# Altium schematic sheet SCIENCE_LED_BOARD.SchDoc
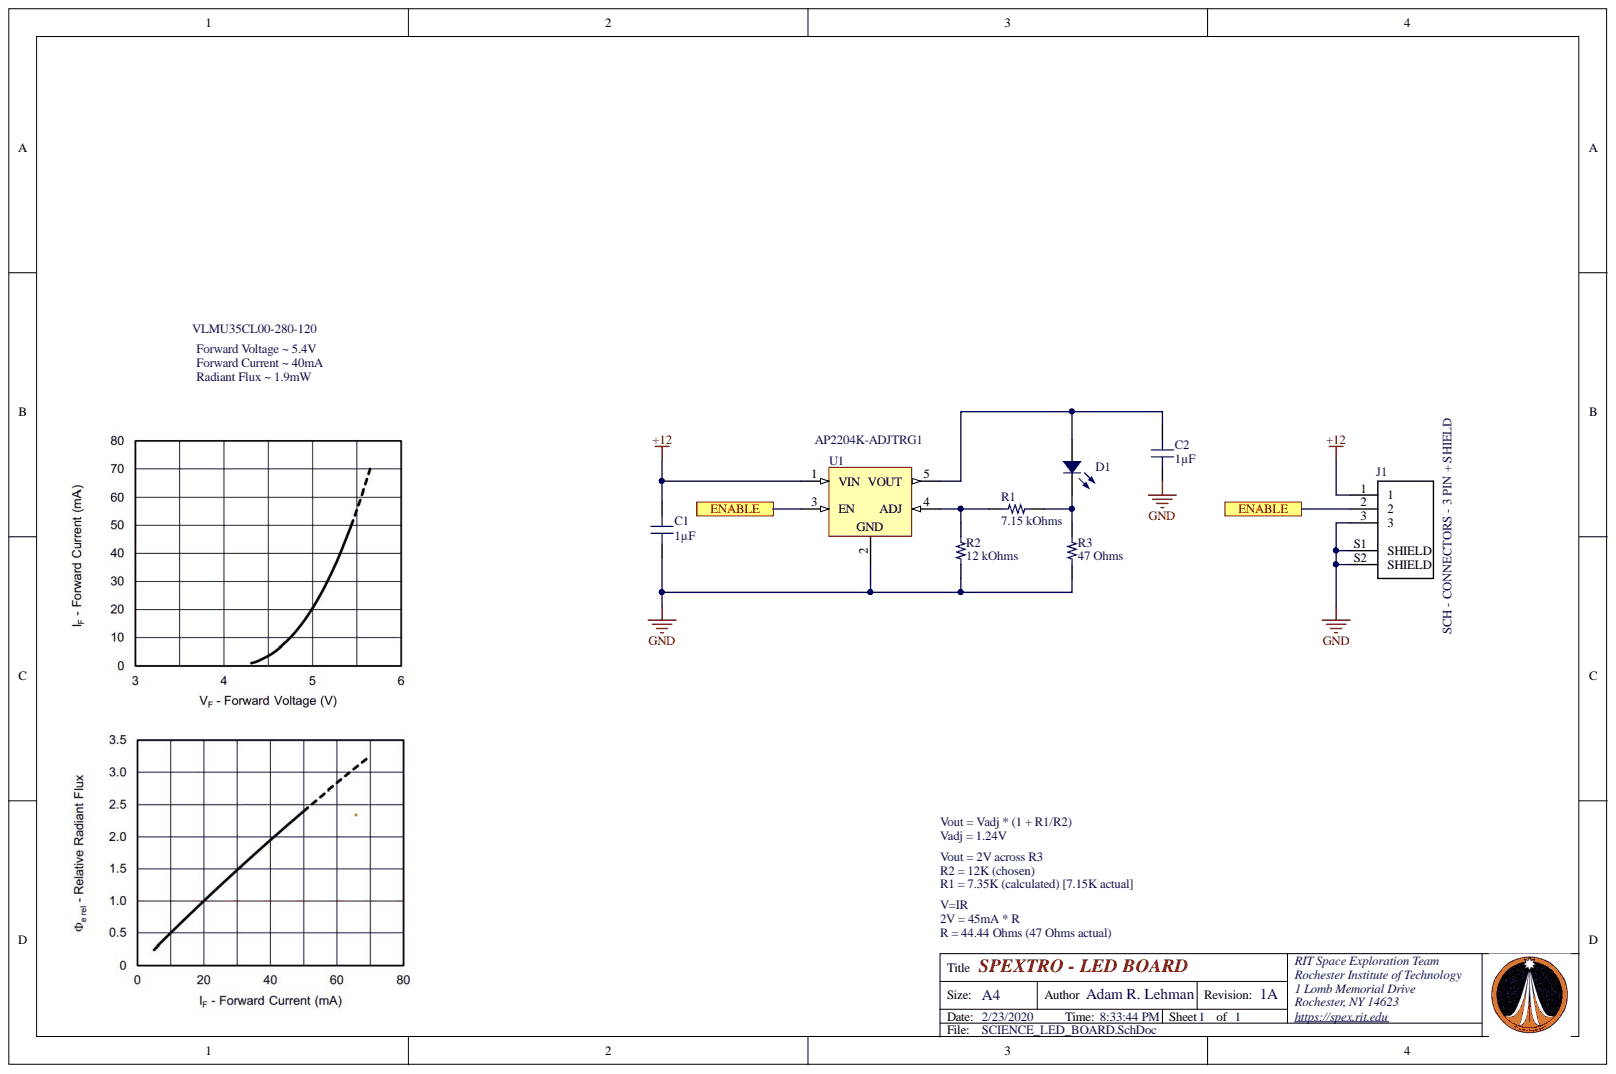
[diagram p0: SCIENCE_LED_BOARD.SchDoc]

## Parts
C1  1µF  [CAP 0603_1608]
C2  1µF  [CAP 0603_1608]
D1  =VALUE  [PCB - LED - VISHAY 1414_3535 UV]
J1  SCH - CONNECTORS - 3 PIN + SHIELD  [PCB - CONNECTOR - MOLEX - PICO-LOCK 5037630391]
R1  7.15 kOhms  [RES 0603_1608]
R2  12 kOhms  [RES 0603_1608]
R3  47 Ohms  [RES 0603_1608]
U1  AP2204K-ADJTRG1  [DIOD-SOT23-5_V]

## Ports
ENABLE

## Nets
+12: C1.2 J1.1 U1.1(VIN)
GND: C1.1 C2.1 J1.3 J1.S1 J1.S2 R2.1 R3.1 U1.2
ENABLE: J1.2 U1.3(EN) ; port ENABLE
NetC2_2: C2.2 D1.1 U1.5(VOUT)
NetD1_2: D1.2 R1.2 R3.2
NetR1_1: R1.1 R2.2 U1.4(ADJ)

## Library data of the parts
C1 C2 = CC0603KRX5R8BB105: CAP CER 1UF 25V X5R 0603; Manufacturer: Yageo; Database Table Name: Capacitors - Ceramic - 0603; Tolerance: ±10%; Applications: General Purpose; Capacitance: 1µF; Component Kind: Standard; ComponentLink1Description: Datasheet; ComponentLink1URL: https://www.yageo.com/upload/media/product/productsearch/datasheet/mlcc/UPY-GPHC_X5R_4V-to-50V_25.pdf; ComponentLink2Description: DigiKey Link; ComponentLink2URL: http://digikey.com/product-detail/en/yageo/CC0603KRX5R8BB105/311-1445-1-ND/2833751; Device Package: 0603 (1608 Metric); LastUpdated: 1/21/2020 12:02:05 AM; Library Name: Celestial Altium Library - altium_library.DbLib; Lifecycle Status: Active; Minimum Order: 1; Mounting Type: Surface Mount, MLCC; Operating Temperature: -55°C ~ 85°C; Package / Case: 0603 (1608 Metric); Packaging: TapeAndReel; PartId: 5146; Part Number: CC0603KRX5R8BB105; Part Status: Active; Price: 0.01; Series: CC; Size / Dimension: 0.063" L x 0.031" W (1.60mm x 0.80mm); Supplier 1: DigiKey; Supplier Part Number 1: 311-1445-1-ND; Temperature Coefficient: X5R; Thickness (Max): 0.035" (0.90mm); Value: 1µF; Voltage - Rated: 25V
D1 = LED: Chip LED; Component Kind: Standard; Library Name: ScienceLEDLibrary.IntLib
J1 = SCH - CONNECTORS - 3 PIN + SHIELD: Connector Header Surface Mount, Right Angle 4 position 0.039 _1.00mm_; MF: Molex; MP: 5037630491; Availability: Unavailable; Component Kind: Standard; Package: None; Price: None; SnapEDA_Link: https://www.snapeda.com/parts/5037630491/Molex/view-part/3215405/?ref=snap; Library Name: ScienceLEDLibrary.IntLib
R1 = RMCF0603FT7K15: RES 7.15K OHM 1% 1/10W 0603; Manufacturer: Stackpole Electronics Inc; Database Table Name: Resistors - Surface Mount - 0603; Power (Watts): 0.1W, 1/10W; Temperature Coefficient: ±100ppm/°C; Component Kind: Standard; ComponentLink1Description: Datasheet; ComponentLink1URL: https://www.seielect.com/catalog/sei-packaging.pdf; ComponentLink2Description: DigiKey Link; ComponentLink2URL: http://digikey.com/product-detail/en/stackpole-electronics-inc/RMCF0603FT7K15/RMCF0603FT7K15CT-ND/1943048; Composition: Thick Film; Device Package: 0603 (1608 Metric); Features: Automotive AEC-Q200; Height - Seated (Max): 0.022" (0.55mm); LastUpdated: 1/21/2020 12:17:41 AM; Library Name: Celestial Altium Library - altium_library.DbLib; Lifecycle Status: Active; Minimum Order: 1; Number of Terminations: 2; Operating Temperature: -55°C ~ 155°C; Package / Case: 0603 (1608 Metric); Packaging: CutTape; PartId: 22551; Part Number: RMCF0603FT7K15; Part Status: Active; Price: 0.10; Ratings: AEC-Q200; Resistance: 7.15 kOhms; Series: RMCF; Size / Dimension: 0.061" L x 0.031" W (1.55mm x 0.80mm); Supplier 1: DigiKey; Supplier Device Package: 0603; Supplier Part Number 1: RMCF0603FT7K15CT-ND; Tolerance: ±1%; Value: 7.15 kOhms
R2 = RMCF0603FT12K0: RES 12K OHM 1% 1/10W 0603; Manufacturer: Stackpole Electronics Inc; Database Table Name: Resistors - Surface Mount - 0603; Power (Watts): 0.1W, 1/10W; Temperature Coefficient: ±100ppm/°C; Component Kind: Standard; ComponentLink1Description: Datasheet; ComponentLink1URL: https://www.seielect.com/catalog/sei-rmcf_rmcp.pdf; ComponentLink2Description: DigiKey Link; ComponentLink2URL: http://digikey.com/product-detail/en/stackpole-electronics-inc/RMCF0603FT12K0/RMCF0603FT12K0CT-ND/1943062; Composition: Thick Film; Device Package: 0603 (1608 Metric); Features: Automotive AEC-Q200; Height - Seated (Max): 0.022" (0.55mm); LastUpdated: 1/21/2020 12:22:42 AM; Library Name: Celestial Altium Library - altium_library.DbLib; Lifecycle Status: Active; Minimum Order: 1; Number of Terminations: 2; Operating Temperature: -55°C ~ 155°C; Package / Case: 0603 (1608 Metric); Packaging: CutTape; PartId: 10636; Part Number: RMCF0603FT12K0; Part Status: Active; Price: 0.10; Ratings: AEC-Q200; Resistance: 12 kOhms; Series: RMCF; Size / Dimension: 0.061" L x 0.031" W (1.55mm x 0.80mm); Supplier 1: DigiKey; Supplier Device Package: 0603; Supplier Part Number 1: RMCF0603FT12K0CT-ND; Tolerance: ±1%; Value: 12 kOhms
R3 = RMCF0603FT47R0: RES 47 OHM 1% 1/10W 0603; Manufacturer: Stackpole Electronics Inc; Database Table Name: Resistors - Surface Mount - 0603; Power (Watts): 0.1W, 1/10W; Temperature Coefficient: ±100ppm/°C; Component Kind: Standard; ComponentLink1Description: Datasheet; ComponentLink1URL: https://www.seielect.com/catalog/sei-rmcf_rmcp.pdf; ComponentLink2Description: DigiKey Link; ComponentLink2URL: http://digikey.com/product-detail/en/stackpole-electronics-inc/RMCF0603FT47R0/RMCF0603FT47R0CT-ND/1942954; Composition: Thick Film; Device Package: 0603 (1608 Metric); Features: Automotive AEC-Q200; Height - Seated (Max): 0.022" (0.55mm); LastUpdated: 1/21/2020 12:22:37 AM; Library Name: Celestial Altium Library - altium_library.DbLib; Lifecycle Status: Active; Minimum Order: 1; Number of Terminations: 2; Operating Temperature: -55°C ~ 155°C; Package / Case: 0603 (1608 Metric); Packaging: CutTape; PartId: 10672; Part Number: RMCF0603FT47R0; Part Status: Active; Price: 0.10; Ratings: AEC-Q200; Resistance: 47 Ohms; Series: RMCF; Size / Dimension: 0.061" L x 0.031" W (1.55mm x 0.80mm); Supplier 1: DigiKey; Supplier Device Package: 0603; Supplier Part Number 1: RMCF0603FT47R0CT-ND; Tolerance: ±1%; Value: 47 Ohms
U1 = CMP-2000-05784-1: Wide Input Voltage Range, Adjustable Output, 150 mA, -40 to 125 degC, 5-Pin SOT23, RoHS, Tape and Reel; Brand: DiodesIncorporated; Dropout Voltage - Max: 500mV; Input Voltage MAX: 24V; Input Voltage MIN: 2.3V; Line Regulation: 0.05%; Load Regulation: 0.5%; Number of Outputs: 1Output; Output Current: 200mA; Output Voltage: Adj; PSRR / Ripple Rejection - Typ: 60dB; Reference Voltage: 1.24V; Unit Weight: 0.001058oz; Component Kind: Standard; Datasheet URL: https://www.diodes.com/_files/datasheets/AP2204.pdf; Datasheet Version: Rev. 3-2, 06/2016; Factory Pack Quantity: 3000; Library Name: Altium Content Vault; Manufacturer: DiodesIncorporated; Manufacturer URL: http://www.diodes.com/; Maximum Operating Temperature: +85C; Minimum Operating Temperature: -40C; Mounting Style: SMD/SMT; Mounting Technology: SurfaceMount; Output Type: Adjustable; Package / Case: SOT-23-5; Package Description: 5-Pin Small Outline Transistor, Body 2.96 x 1.6 mm, Pitch 0.95 mm; Package Reference: SOT23-5; Packaging: Reel; Product: LDORegulators; Product Category: LDOVoltageRegulators; RoHS: True; Series: AP2204K; Type: ULDORegulator
